# Altium schematic sheet [1]Power.SchDoc
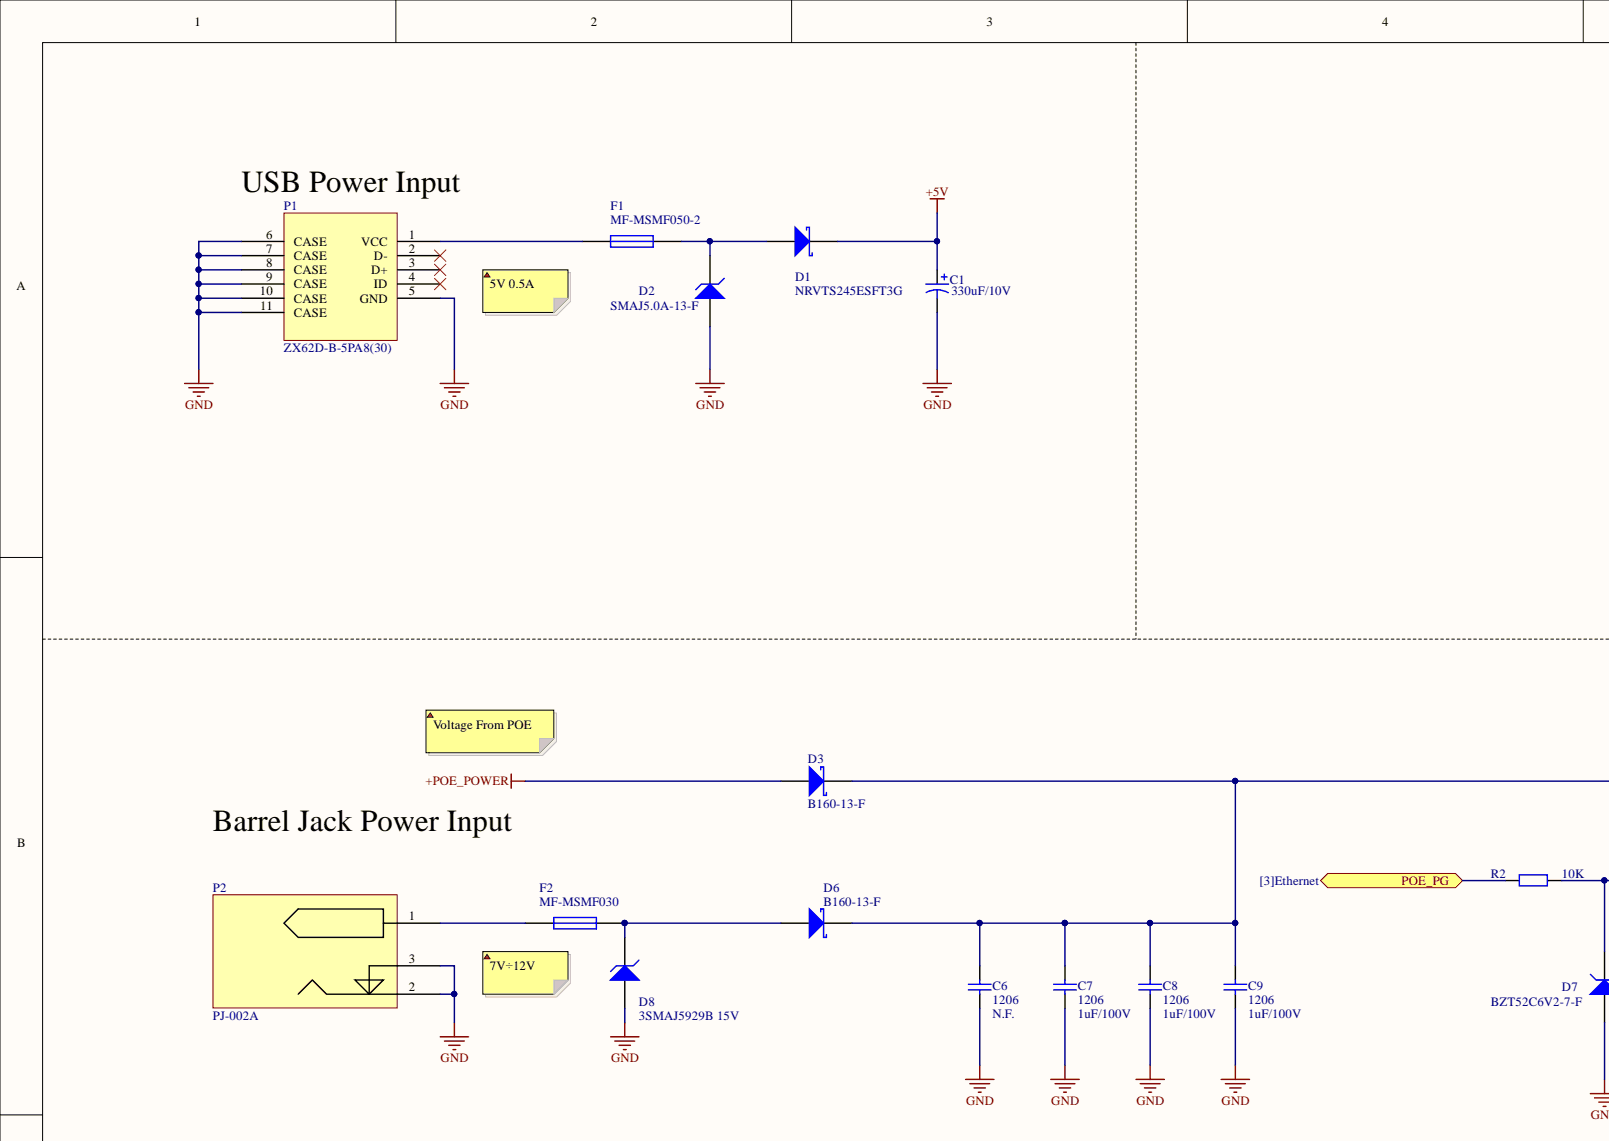
[diagram p0: [1]Power.SchDoc, top left]
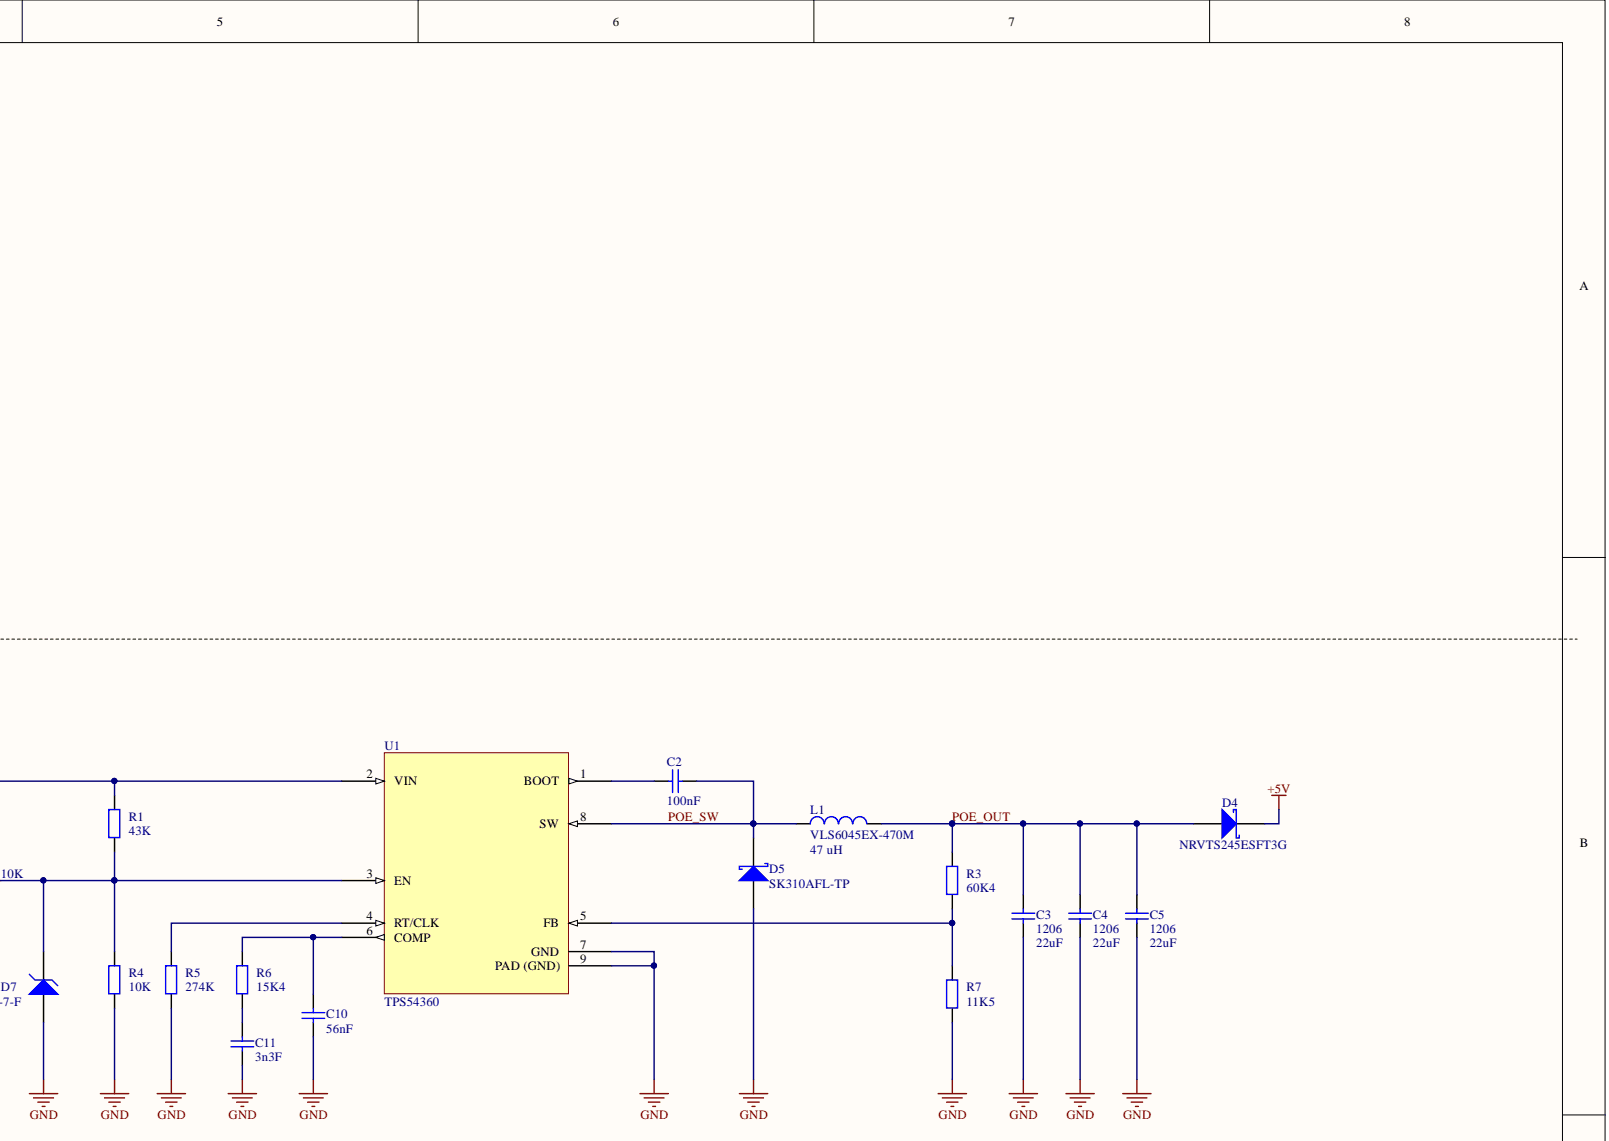
[diagram p1: [1]Power.SchDoc, top right]
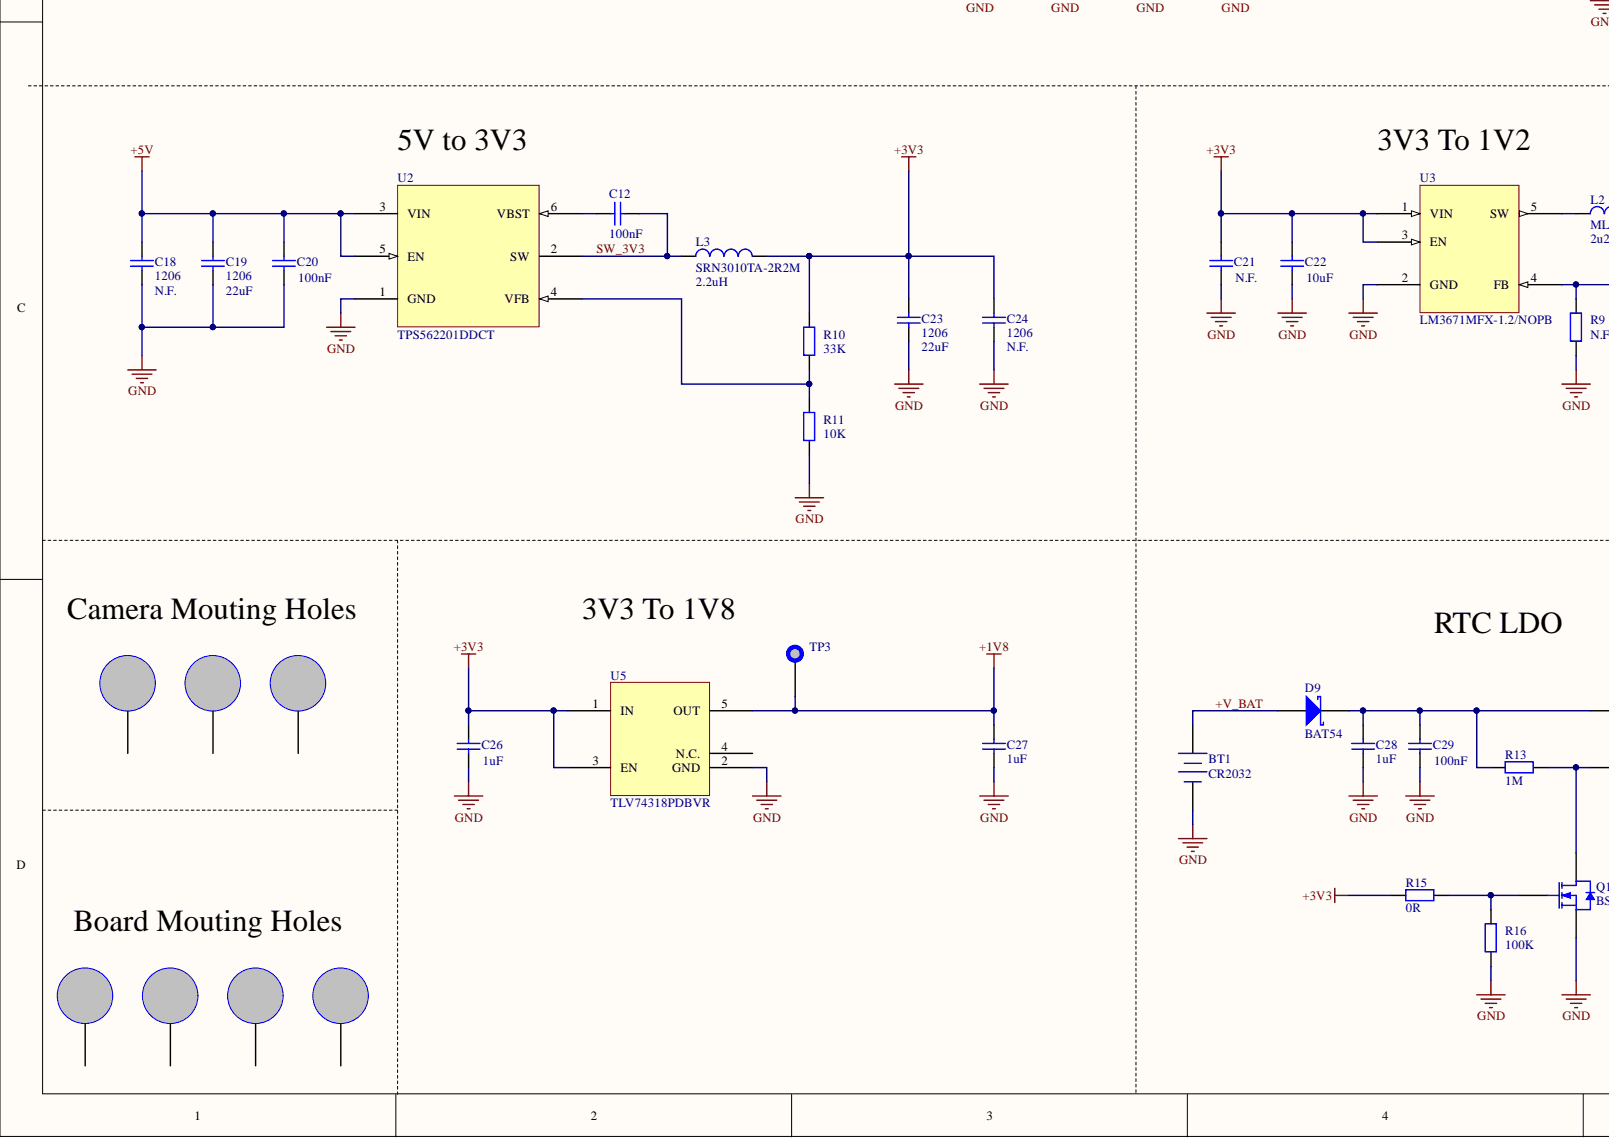
[diagram p2: [1]Power.SchDoc, bottom left]
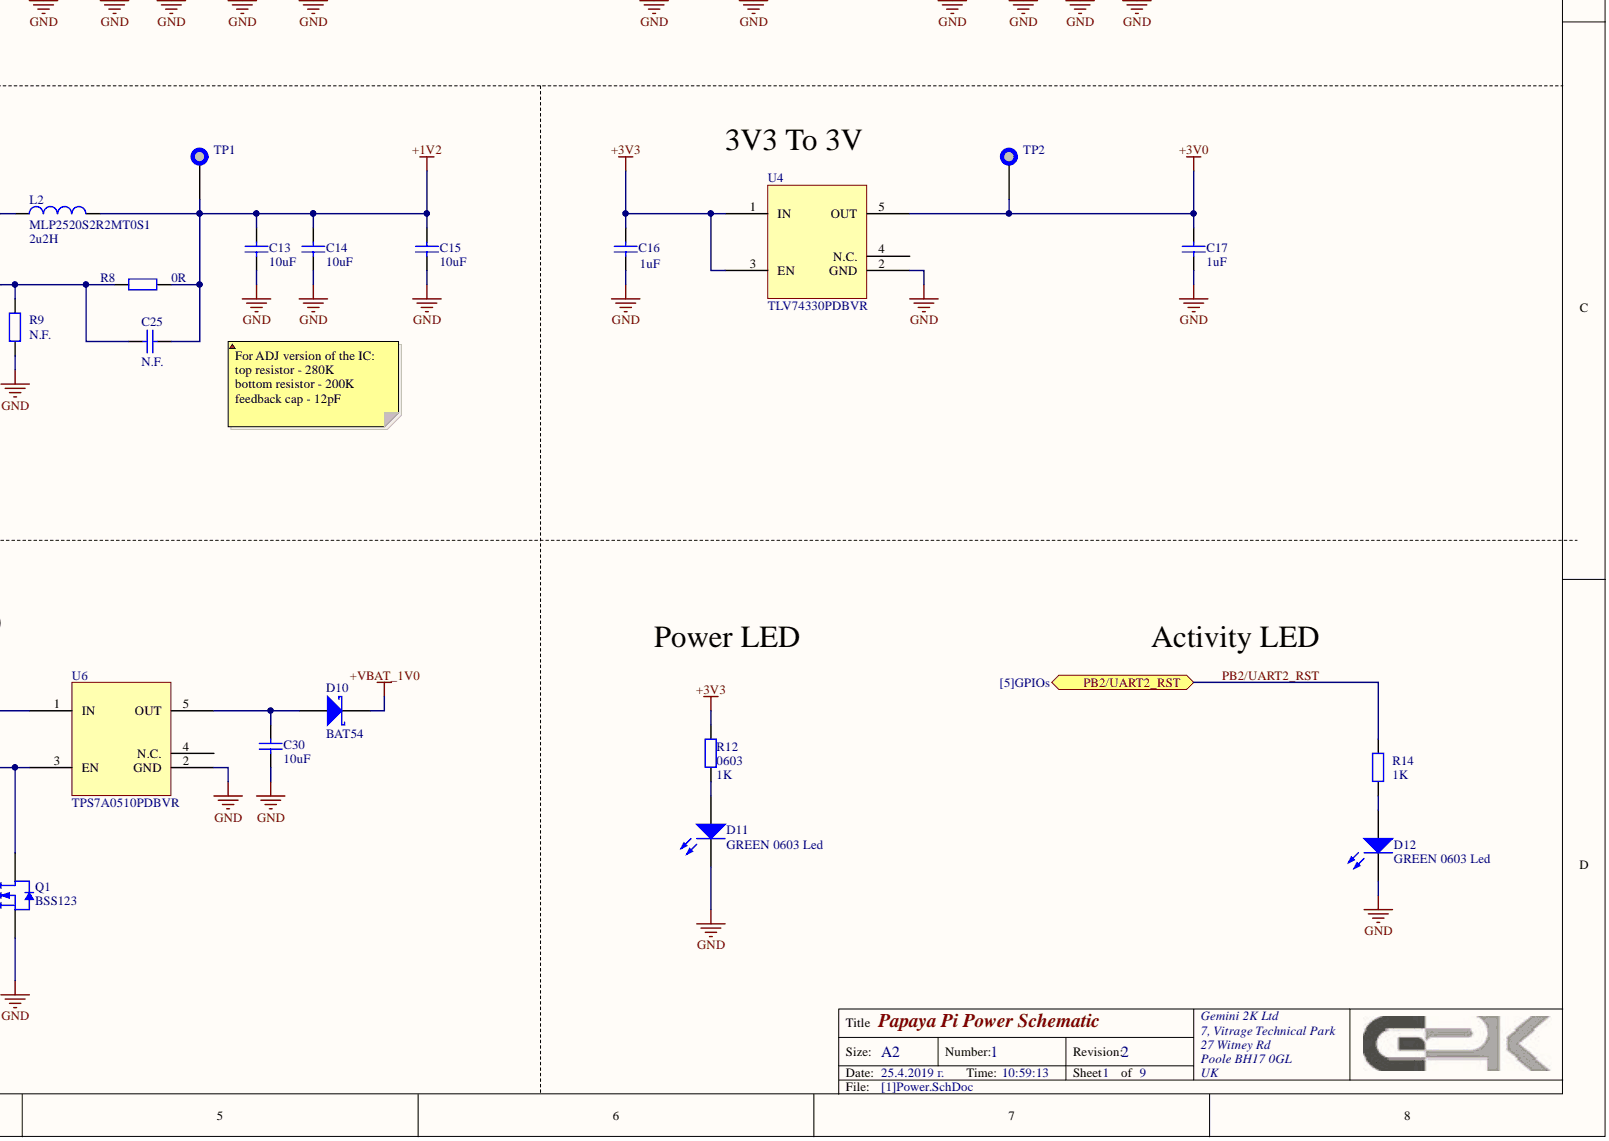
[diagram p3: [1]Power.SchDoc, bottom right]

## Parts
BT1  CR2032  [BAT-HLD-001]
C1  330uF/10V  [8x10mm Electrolytic cap]
C2  value 100nF  [1608[0603]]  (hidden comment: 0603)
C3  1206  value 22uF  [C1206]
C4  1206  value 22uF  [C1206]
C5  1206  value 22uF  [C1206]
C6  1206  value N.F.  [C1206]
C7  1206  value 1uF/100V  [C1206]
C8  1206  value 1uF/100V  [C1206]
C9  1206  value 1uF/100V  [C1206]
C10  value 56nF  [1608[0603]]  (hidden comment: 0603)
C11  value 3n3F  [1608[0603]]  (hidden comment: 0603)
C12  value 100nF  [1608[0603]]  (hidden comment: 0603)
C13  value 10uF  [1608[0603]]  (hidden comment: 0603)
C14  value 10uF  [1608[0603]]  (hidden comment: 0603)
C15  value 10uF  [1608[0603]]  (hidden comment: 0603)
C16  value 1uF  [1608[0603]]  (hidden comment: 0603)
C17  value 1uF  [1608[0603]]  (hidden comment: 0603)
C18  1206  value N.F.  [C1206]
C19  1206  value 22uF  [C1206]
C20  value 100nF  [1608[0603]]  (hidden comment: 0603)
C21  value N.F.  [1608[0603]]  (hidden comment: 0603)
C22  value 10uF  [1608[0603]]  (hidden comment: 0603)
C23  1206  value 22uF  [C1206]
C24  1206  value N.F.  [C1206]
C25  value N.F.  [1608[0603]]  (hidden comment: 0603)
C26  value 1uF  [1608[0603]]  (hidden comment: 0603)
C27  value 1uF  [1608[0603]]  (hidden comment: 0603)
C28  value 1uF  [1608[0603]]  (hidden comment: 0603)
C29  value 100nF  [1608[0603]]  (hidden comment: 0603)
C30  value 10uF  [1608[0603]]  (hidden comment: 0603)
D1  NRVTS245ESFT3G  [SOD-123FL]
D2  SMAJ5.0A-13-F  [SMA]
D3  B160-13-F  [SMA]
D4  NRVTS245ESFT3G  [SOD-123FL]
D5  SK310AFL-TP  [DO-221AC-2]
D6  B160-13-F  [SMA]
D7  BZT52C6V2-7-F  [SOD123]
D8  3SMAJ5929B 15V  [SMA]
D9  BAT54  [SOT-23_N]
D10  BAT54  [SOT-23_N]
D11  GREEN 0603 Led  [LED0603]
D12  GREEN 0603 Led  [LED0603]
F1  MF-MSMF050-2  [CAPC4532L]
F2  MF-MSMF030  [CAPC4532L]
L1  VLS6045EX-470M  value 47 uH  [VLS-EX Series Inductors]
L2  MLP2520S2R2MT0S1  value 2u2H  [1008 Inductor Case]
L3  SRN3010TA-2R2M  value 2.2uH  [SRN3012TA Series Inductors]
MH1  Mouting Hole  [M3 Mounting Hole]  (no BOM)
MH2  Mouting Hole  [M3 Mounting Hole]  (no BOM)
MH3  Mouting Hole  [M3 Mounting Hole]  (no BOM)
MH4  Mouting Hole  [M3 Mounting Hole]  (no BOM)
MH5  Mouting Hole  [M3 Mounting Hole]  (no BOM)
MH6  Mouting Hole  [M3 Mounting Hole]  (no BOM)
MH7  Mouting Hole  [M3 Mounting Hole]  (no BOM)
P1  ZX62D-B-5PA8(30)  [ZX62D-B-5PA8(30)]
P2  PJ-002A  [PJ-002A]
Q1  BSS123  [SOT23_N]
R1  value 43K  [J1-0603]  (hidden comment: 0603)
R2  value 10K  [J1-0603]  (hidden comment: 0603)
R3  value 60K4  [J1-0603]  (hidden comment: 0603)
R4  value 10K  [J1-0603]  (hidden comment: 0603)
R5  value 274K  [J1-0603]  (hidden comment: 0603)
R6  value 15K4  [J1-0603]  (hidden comment: 0603)
R7  value 11K5  [J1-0603]  (hidden comment: 0603)
R8  value 0R  [J1-0603]  (hidden comment: 0603)
R9  value N.F.  [J1-0603]  (hidden comment: 0603)
R10  value 33K  [J1-0603]  (hidden comment: 0603)
R11  value 10K  [J1-0603]  (hidden comment: 0603)
R12  0603  value 1K  [J1-0603]
R13  value 1M  [J1-0603]  (hidden comment: 0603)
R14  value 1K  [J1-0603]  (hidden comment: 0603)
R15  value 0R  [J1-0603]  (hidden comment: 0603)
R16  value 100K  [J1-0603]  (hidden comment: 0603)
TP1  TEST_POINT  [TEST_POINT]  (value not drawn)  (no BOM)
TP2  TEST_POINT  [TEST_POINT]  (value not drawn)  (no BOM)
TP3  TEST_POINT  [TEST_POINT]  (value not drawn)  (no BOM)
U1  TPS54360  [HSOIC-8]
U2  TPS562201DDCT  [SOT100P275-6L]
U3  LM3671MFX-1.2/NOPB  [SOT23-5AN]
U4  TLV74330PDBVR  [SOT23-5AN]
U5  TLV74318PDBVR  [SOT23-5AN]
U6  TPS7A0510PDBVR  [SOT23-5AN]

## Ports
PB2/UART2_RST, POE_PG

## Nets
+1V2: C13.2 C14.2 C15.2 C25.1 L2.2 R8.1 TP1.1
+1V8: C27.2 TP3.1 U5.5(OUT)
+3V0: C17.2 TP2.1 U4.5(OUT)
+3V3: C16.2 C21.2 C22.2 C23.2 C24.2 C26.2 L3.2 R10.2 R12.1 R15.1 U3.1(VIN) U3.3(EN) U4.1(IN) U4.3(EN) U5.1(IN) U5.3(EN)
+5V: C1.1 C18.2 C19.2 C20.2 D1.2 D4.2 U2.3(VIN) U2.5(EN)
+POE_POWER: D3.1
+VBAT_1V0: D10.3
GND: BT1.2 C1.2 C3.1 C4.1 C5.1 C6.1 C7.1 C8.1 C9.1 C10.1 C11.1 C13.1 C14.1 C15.1 C16.1 C17.1 C18.1 C19.1 C20.1 C21.1 C22.1 C23.1 C24.1 C26.1 C27.1 C28.1 C29.1 C30.1 D2.1 D5.1 D7.1 D8.1 D11.2 D12.2 P1.5(GND) P1.6(CASE) P1.7(CASE) P1.8(CASE) P1.9(CASE) P1.10(CASE) P1.11(CASE) P2.2 P2.3 Q1.2 R4.1 R5.1 R7.1 R9.1 R11.1 R16.1 U1.7(GND) U1.9(PAD_(GND)) U2.1(GND) U3.2(GND) U4.2(GND) U5.2(GND) U6.2(GND)
+V_BAT: BT1.1 D9.1
PB2/UART2_RST: R14.1 ; port PB2/UART2_RST
POE_OUT: C3.2 C4.2 C5.2 D4.1 L1.2 R3.2
POE_PG: R2.2 ; port POE_PG
POE_SW: C2.1 D5.2 L1.1 U1.8(SW)
SW_3V3: C12.1 L3.1 U2.2(SW)
NetC2_2: C2.2 U1.1(BOOT)
NetC6_2: C6.2 C7.2 C8.2 C9.2 D3.2 D6.2 R1.2 U1.2(VIN)
NetC10_2: C10.2 R6.2 U1.6(COMP)
NetC11_2: C11.2 R6.1
NetC12_2: C12.2 U2.6(VBST)
NetC25_2: C25.2 R8.2 R9.2 U3.4(FB)
NetC28_2: C28.2 C29.2 D9.3 R13.2 U6.1(IN)
NetC30_2: C30.2 D10.1 U6.5(OUT)
NetD1_1: D1.1 D2.2 F1.2
NetD6_1: D6.1 D8.2 F2.2
NetD7_2: D7.2 R1.1 R2.1 R4.2 U1.3(EN)
NetD11_1: D11.1 R12.2
NetD12_1: D12.1 R14.2
NetF1_1: F1.1 P1.1(VCC)
NetF2_1: F2.1 P2.1
NetL2_1: L2.1 U3.5(SW)
NetQ1_1: Q1.1 R15.2 R16.2
NetQ1_3: Q1.3 R13.1 U6.3(EN)
NetR3_1: R3.1 R7.2 U1.5(FB)
NetR5_2: R5.2 U1.4(RT/CLK)
NetR10_1: R10.1 R11.2 U2.4(VFB)

Not connected: MH1 1; MH2 1; MH3 1; MH4 1; MH5 1; MH6 1; MH7 1; P1 2(D-) 3(D+) 4(ID); U4 4(N.C.); U5 4(N.C.); U6 4(N.C.)

## Library data of the parts
BT1 = Battery: Multicell Battery; Manufacturer Name: Linx Technologies; Component Kind: Standard; Distributor Link: https://eu.mouser.com/ProductDetail/Linx-Technologies/BAT-HLD-001?qs=K5ta8V%252bWhta7hbVGfm4dqA%3D%3D; LatestRevisionDate: 17-Jul-2002; LatestRevisionNote: Re-released for DXP Platform.; Library Name: Miscellaneous Devices.IntLib; Manufacturer Part No.: BAT-HLD-001; PackageDescription: Battery; 2 Leads; PackageReference: BAT-2; Published: 24-Mar-1999; Publisher: Altium Limited
C1 = Cap Pol3: Polarized Capacitor (Surface Mount); Manufacturer Name: Nichicon; Manufacturer Part No.: UWT1A331MNL1GS; G2K_PN: G-CAP-C22UF-UUR2A220MNL1GS-01; Component Kind: Standard; Distributor Link: https://eu.mouser.com/ProductDetail/Nichicon/UWT1A331MNL1GS?qs=%2Fha2pyFadugs9ug9Ltm1CEeY4iN9OVWAGUV2SBjTxwrrhxchLNuxOQ%3D%3D; Library Name: Miscellaneous Devices.IntLib; Value: 330uF/10V
C2 C12 C20 C29 = Cap: Capacitor; Note: We have them in Bulgaria; Case Code (in): 0603; Component Kind: Standard; Distributor Link: http://uk.farnell.com/kemet/c0603x104k4ractu/cap-mlcc-x7r-100nf-16v-0603/dp/1414027; LatestRevisionDate: 17-Jul-2002; LatestRevisionNote: Re-released for DXP Platform.; Library Name: Miscellaneous Devices.IntLib; PackageDescription: Radial Cap, Thru-Hole; 2 Leads; 0.3 in Pin Spacing; PackageReference: RAD-0.3; Published: 8-Jun-2000; Signal Integrity: Cap; Simulation: CAP; Publisher: Altium Limited
C3-C9 C13-C19 C21-C28 C30 = Cap: Capacitor; Component Kind: Standard; LatestRevisionDate: 17-Jul-2002; LatestRevisionNote: Re-released for DXP Platform.; Library Name: Miscellaneous Devices.IntLib; PackageDescription: Radial Cap, Thru-Hole; 2 Leads; 0.3 in Pin Spacing; PackageReference: RAD-0.3; Published: 8-Jun-2000; Signal Integrity: Cap; Simulation: CAP; Publisher: Altium Limited
  C3-C5 C19 C23: Case Code (in): 1206; Distributor Link: https://eu.mouser.com/ProductDetail/Taiyo-Yuden/EMK316BB7226ML-T?qs=sGAEpiMZZMvsSlwiRhF8qty1BJkHnpVYblTC1OBiWryHLK40sNpKgw%3d%3d
  C6 C18 C24: Case Code (in): 1206
  C7-C9: Case Code (in): 1206; Distributor Link: https://uk.farnell.com/avx/12061c105kat2a/cap-1-f-100v-10-x7r-1206/dp/1657939
  C13-C15 C22 C30: Case Code (in): 0603; Distributor Link: https://eu.mouser.com/ProductDetail/Taiyo-Yuden/JMK107ABJ106MA-T?qs=sGAEpiMZZMvsSlwiRhF8qtYrDJZp5VWM0KJHW32Kpw4hdLhJsFnoCA%3d%3d
  C16 C17 C26-C28: Case Code (in): 0603; Distributor Link: https://eu.mouser.com/ProductDetail/Taiyo-Yuden/LMK107B7105KA-T?qs=sGAEpiMZZMvsSlwiRhF8qgJCQaFFkNE%2fsetabqB5pzo%3d
  C21 C25: Case Code (in): 0603
C10 C11 = Cap: Capacitor; Note: Get a lot more of those.; Case Code (in): 0603; Component Kind: Standard; LatestRevisionDate: 17-Jul-2002; LatestRevisionNote: Re-released for DXP Platform.; Library Name: Miscellaneous Devices.IntLib; PackageDescription: Radial Cap, Thru-Hole; 2 Leads; 0.3 in Pin Spacing; PackageReference: RAD-0.3; Published: 8-Jun-2000; Signal Integrity: Cap; Simulation: CAP; Publisher: Altium Limited
  C10: Distributor Link: https://uk.farnell.com/kemet/c0603c563k5ractu/cap-0-056-f-50v-10-x7r-0603/dp/1865538
  C11: Distributor Link: https://uk.farnell.com/multicomp/mc0603b332k500ct/cap-3300pf-50v-10-x7r-0603/dp/1759095
D1 D4 = D Schottky: Schottky Diode; Manufacturer Name: ON Semiconductor; Component Kind: Standard; Library Name: Miscellaneous Devices.IntLib; Manufacturer Part No.: NRVTS245ESFT3G
  D1: Distributor Link: https://eu.mouser.com/ProductDetail/ON-Semiconductor/NRVTS245ESFT3G?qs=%2fha2pyFaduhXdiVT9CFLR0%2fbKNWkiKHdgiRqQBSSTZVuU0rdVrgZUQ%3d%3d
  D4: Distributor Link: https://eu.mouser.com/ProductDetail/ON-Semiconductor/NRVTS245ESFT3G?qs=k6dkFvHqcvCQU%252bJeAqXeng%3D%3D
D2 = D Zener: Zener Diode; Manufacturer Name: Diodes Incorporated; G2K_PN: G-ZEN-SMAJ5.0A-01; Component Kind: Standard; Distributor Link: https://eu.mouser.com/ProductDetail/Diodes-Incorporated/SMAJ50A-13-F?qs=%2fha2pyFaduh7RhMkydd9YvFtdDGNP%252brahhrS%2fZjE8zmRORqU3Z0Klg%3d%3d; LatestRevisionDate: 17-Jul-2002; LatestRevisionNote: Re-released for DXP Platform.; Library Name: Miscellaneous Devices.IntLib; Manufacturer Part No.: SMAJ5.0A-13-F; PackageReference: DIODE-0.7; Published: 8-Jun-2000; Signal Integrity: D Zener; Simulation: ZENER; Publisher: Altium Limited
D3 D6 = D Schottky: Schottky Diode; Manufacturer Name: Diodes Incorporated; Code_JEDEC: DO-214-AA; Component Kind: Standard; Distributor Link: https://eu.mouser.com/ProductDetail/Diodes-Incorporated/B160-13-F?qs=SQxTiZ%252BdFLDKHRPMwjkKCg%3D%3D; LatestRevisionDate: 17-Jul-2002; LatestRevisionNote: Re-released for DXP Platform.; Library Name: Miscellaneous Devices.IntLib; Manufacturer Part No.: B160-13-F; PackageReference: SMB; Published: 8-Jun-2000; Publisher: Altium Limited
D5 = D Schottky: Schottky Diode; Manufacturer Name: Micro Commercial Components (MCC); Note: Get about 5 more of this; Code_JEDEC: DO-214-AA; Component Kind: Standard; Distributor Link: https://eu.mouser.com/ProductDetail/Micro-Commercial-Components-MCC/SK310AFL-TP?qs=%2F9jDaHz9Z2jGR2bZfe%252bg%2FQ%3D%3D; LatestRevisionDate: 17-Jul-2002; LatestRevisionNote: Re-released for DXP Platform.; Library Name: Miscellaneous Devices.IntLib; Manufacturer Part No.: SK310AFL-TP; PackageDescription: DO-214-AA/SMB; 2 C-Bend Leads; Body 5.3 x 3.6 mm, inc. leads (LxW); PackageReference: SMB; Published: 8-Jun-2000; Signal Integrity: D Schottky; Simulation: SKYDIODE; Publisher: Altium Limited
D7 = D Zener: Zener Diode; Manufacturer Name: Diodes Incorporated; Component Kind: Standard; Distributor Link: https://eu.mouser.com/ProductDetail/Diodes-Incorporated/BZT52C6V2-7-F?qs=sGAEpiMZZMtQ8nqTKtFS%2FB3EXccNg%252BLy7eRreKkDmjQ%3D; LatestRevisionDate: 17-Jul-2002; LatestRevisionNote: Re-released for DXP Platform.; Library Name: Miscellaneous Devices.IntLib; Manufacturer Part No.: BZT52C6V2-7-F; PackageDescription: Diode, Thru-Hole; 2 Leads; 0.7 in Pin Spacing; PackageReference: DIODE-0.7; Published: 8-Jun-2000; Signal Integrity: D Zener; Simulation: ZENER; Publisher: Altium Limited
D8 = D Zener: Zener Diode; Manufacturer Name: Micro Commercial Components (MCC); Component Kind: Standard; Distributor Link: https://eu.mouser.com/ProductDetail/Micro-Commercial-Components-MCC/SMAJ5929B-TP?qs=sGAEpiMZZMtQ8nqTKtFS%2FNu8%252Bgw6C5jShKXC53ar3gg%3D; LatestRevisionDate: 17-Jul-2002; LatestRevisionNote: Re-released for DXP Platform.; Library Name: Miscellaneous Devices.IntLib; Manufacturer Part No.: SMAJ5929B; PackageReference: DIODE-0.7; Published: 8-Jun-2000; Signal Integrity: D Zener; Simulation: ZENER; Publisher: Altium Limited
D9 D10 = Diode BAT17: Silicon RF Schottky Diode for Mixer Applications in the VHF/UHF Range; Manufacturer Name: ON SEMICONDUCTOR; Manufacturer Part No.: BAT54LT1G; Component Kind: Standard; ComponentLink1Description: Manufacturer Link; ComponentLink1URL: http://www.infineon.com/; ComponentLink2Description: Datasheet; ComponentLink2URL: http://www.infineon.com/upload/Document/cmc_upload/documents/009/363/bat17series.pdf; DatasheetVersion: Oct-1999; Distributor Link: https://uk.farnell.com/on-semiconductor/bat54lt1g/diode-signal-30v-sot-23/dp/1431113; LatestRevisionDate: 29-May-2009; LatestRevisionNote: IPC-7351 Footprint Added.; Library Name: Miscellaneous Devices.IntLib; PackageDescription: 3-Pin SOT-23 Package 0.95 mm Pitch, 2.5 mm Lead Span; PackageReference: SOT-23; PackageVersion: Sep-2001; Published: 21-Mar-2003; Simulation: D188; Publisher: Altium Limited
D11 D12 = LED0: Typical INFRARED GaAs LED; Manufacturer Name: KINGBRIGHT; Manufacturer Part No.: KPT-1608CGCK; Note: We have them in Bulgaria; Component Kind: Standard; Distributor Link: http://uk.farnell.com/kingbright/kpt-1608cgck/led-green-0603-smd/dp/2099220; LatestRevisionDate: 08-Jul-2005; LatestRevisionNote: Mech Layer 1 removed.; Library Name: Miscellaneous Devices.IntLib; PackageDescription: LED; 2 Leads; PackageReference: LED-0; Published: 8-Jun-2000; Simulation: LED0; Publisher: Altium Limited
F1 F2 = Fuse 1: Fuse; Manufacturer Name: Bourns; Component Kind: Standard; LatestRevisionDate: 17-Jul-2002; LatestRevisionNote: Re-released for DXP Platform.; Library Name: Miscellaneous Devices.IntLib; PackageReference: PIN-W2/E2.8; Published: 8-Jun-2000; Simulation: FUSE; Publisher: Altium Limited
  F1: Distributor Link: https://uk.farnell.com/bourns/mf-msmf050-2/fuse-ptc-reset-15v-0-5a-smd/dp/9350314RL; Manufacturer Part No.: MF-MSMF050-2
  F2: Distributor Link: https://eu.mouser.com/ProductDetail/Bourns/MF-MSMF030-2?qs=4Enggx7jqVwuh3vnyeU3lA%3D%3D; Manufacturer Part No.: MF-MSMF030
L1 = Inductor: Manufacturer Name: TDK; Code_IEC: 1005; Note: Get about 5 more of this; Code_JEITA: 0402; Component Kind: Standard; Description: Inductor; Distributor Link: https://uk.farnell.com/tdk/vls6045ex-470m/inductor-47uh-1-3a-20-wirewound/dp/2776731RL; LatestRevisionDate: 29-May-2009; LatestRevisionNote: IPC-7351 Footprint Added.; Library Name: Miscellaneous Devices.IntLib; Manufacturer Part No.: VLS6045EX-470M; PackageDescription: Chip Inductor; PackageReference: 0402-A; Published: 8-Jun-2000; Signal Integrity: Inductor; Simulation: INDUCTOR; Publisher: Altium Limited
L2 = Inductor: Manufacturer Name: TDK; Code_IEC: 1005; Code_JEITA: 0402; Component Kind: Standard; Description: Inductor; Distributor Link: https://eu.mouser.com/ProductDetail/TDK/MLP2520S2R2MT0S1?qs=%2fha2pyFaduh0gxrgKIlsYSXs17RCvloMFZx7ufg9aiWiXRBut5TeuA%3d%3d; LatestRevisionDate: 29-May-2009; LatestRevisionNote: IPC-7351 Footprint Added.; Library Name: Miscellaneous Devices.IntLib; Manufacturer Part No.: MLP2520S2R2MT0S1; PackageDescription: Chip Inductor; PackageReference: 0402-A; Published: 8-Jun-2000; Signal Integrity: Inductor; Simulation: INDUCTOR; Publisher: Altium Limited
L3 = Inductor: Manufacturer Name: Bourns; Manufacturer Part No.: SRN3012TA-2R2M; Code_IEC: 1005; Code_JEITA: 0402; Component Kind: Standard; Description: Inductor; Distributor Link: https://eu.mouser.com/ProductDetail/Bourns/SRN3012TA-2R2M?qs=Vt59ZOdFuWbjnb9HYKJFZA%3D%3D; LatestRevisionDate: 29-May-2009; LatestRevisionNote: IPC-7351 Footprint Added.; Library Name: Miscellaneous Devices.IntLib; PackageDescription: Chip Inductor; PackageReference: 0402-A; Published: 8-Jun-2000; Signal Integrity: Inductor; Simulation: INDUCTOR; Publisher: Altium Limited
P1 = ZX62D-B-5PA8(30): USB Mini Connector Female; Manufacturer Name: HIROSE(HRS); Component Kind: Standard; Distributor Link: https://uk.farnell.com/hirose-hrs/zx62d-b-5pa8-30/micro-usb-2-0-type-b-rcpt-smt/dp/2554981; Manufacturer Part No.: ZX62D-B-5PA8(30); Library Name: PapayaPi.SchLib
P2 = PJ-002A: DC Power Jack Connector; Manufacturer Name: CUI; Component Kind: Standard; Distributor Link: https://eu.mouser.com/ProductDetail/CUI/PJ-002A?qs=WyjlAZoYn51CKfAix9Mngw%3D%3D; Manufacturer Part No.: PJ-002A; Library Name: PapayaPi.SchLib
Q1 = BSS123: N-channel Enhancement Mode Vertical D-MOSFET; Manufacturer Name: ON Semiconductor; Component Kind: Standard; ComponentLink1Description: Manufacturer Link; ComponentLink1URL: http://www.semiconductors.philips.com/; ComponentLink2Description: Datasheet; ComponentLink2URL: http://www.semiconductors.philips.com/acrobat/datasheets/BSS123_3.pdf; DatasheetVersion: Apr-1995; Distributor Link: https://eu.mouser.com/ProductDetail/ON-Semiconductor/BSS123LT1G?qs=sGAEpiMZZMshyDBzk1%2fWizSW%252bwlCH%2fhMYWCTWBfWgg8%3d; LatestRevisionDate: 12-Dec-2006; LatestRevisionNote: IPC-7351 Footprint Added.; Library Name: Philips Discrete MOSFET - Low Power.IntLib; Manufacturer Part No.: BSS123; PackageDescription: 3-Pin SOT-23 Package 0.95 mm Pitch, 2.3 mm Lead Span; PackageReference: SOT23; PackageVersion: May-1999; Published: 17-Sep-2003; Simulation: BSS123; Publisher: Altium Limited
R1-R7 R9-R14 R16 = Res2: Resistor; Case Code (in): 0603; Component Kind: Standard; LatestRevisionDate: 17-Jul-2002; LatestRevisionNote: Re-released for DXP Platform.; Library Name: Miscellaneous Devices.IntLib; PackageDescription: Axial Device, Thru-Hole; 2 Leads; 0.4 in Pin Spacing; PackageReference: AXIAL-0.4; Published: 8-Jun-2000; Signal Integrity: Res; Simulation: RESISTOR; Publisher: Altium Limited
  R1: Distributor Link: https://uk.farnell.com/vishay/crcw060343k0fkea/res-43k-1-0-1w-0603-thick-film/dp/1469809
  R2 R4 R11: Distributor Link: http://uk.farnell.com/multicomp/mcwr06x1002ftl/res-thick-film-10k-1-0-1w-0603/dp/2447230
  R3: Distributor Link: https://uk.farnell.com/vishay/crcw060360k4fkea/res-60k4-1-0-1w-0603-thick-film/dp/2138476
  R5: Distributor Link: https://uk.farnell.com/panasonic-electronic-components/erj3ekf2743v/res-274k-1-0-1w-0603-thick-film/dp/2303263
  R6: Distributor Link: https://uk.farnell.com/panasonic-electronic-components/erj3ekf1542v/res-15k4-1-0-1w-0603-thick-film/dp/2303198
  R7: Distributor Link: https://uk.farnell.com/vishay/crcw060311k5fkea/res-11k5-1-0-1w-0603-thick-film/dp/1469753
  R10: Distributor Link: https://eu.mouser.com/ProductDetail/Yageo/RC0603FR-0733KL?qs=sGAEpiMZZMvdGkrng054t8Tx25L%252bvTaR4CpLvckkWgM%3d
  R12 R14: Distributor Link: https://eu.mouser.com/ProductDetail/ROHM-Semiconductor/ESR03EZPJ102?qs=sGAEpiMZZMvdGkrng054t4QdAY%2fQ0LwehhDMd9V6YVc%3d
  R13: Distributor Link: https://uk.farnell.com/yageo/rc0603fr-071ml/res-1m-1-0-1w-0603-thick-film/dp/9238840
  R16: Distributor Link: https://eu.mouser.com/ProductDetail/Vishay-Draloric/RCA0603100KJNEC?qs=sGAEpiMZZMvdGkrng054twKDKoBh%252bscnvgxPku908uc%3d
R8 R15 = Res2: Resistor; Note: We have them in Bulgaria; Case Code (in): 0603; Component Kind: Standard; Distributor Link: http://uk.farnell.com/yageo/rc0603fr-070rl/res-thick-film-0r-1-0-1w-0603/dp/2309106; LatestRevisionDate: 17-Jul-2002; LatestRevisionNote: Re-released for DXP Platform.; Library Name: Miscellaneous Devices.IntLib; PackageDescription: Axial Device, Thru-Hole; 2 Leads; 0.4 in Pin Spacing; PackageReference: AXIAL-0.4; Published: 8-Jun-2000; Signal Integrity: Res; Simulation: RESISTOR; Publisher: Altium Limited
U1 = TPS54360: 60-V Input, 3.5-A, Step-Down DC/DC Converter With Eco-Mode; Manufacturer Name: Texas Instruments; Manufacturer Part No.: TPS54360DDAR; Note: TPS54360 is NRFND, if its out of stock, get TPS54360B; Component Kind: Standard; Distributor Link: https://eu.mouser.com/ProductDetail/Texas-Instruments/TPS54360DDAR?qs=sGAEpiMZZMtitjHzVIkrqQ%2fpYjwcDHoFDywU%252bodbKiA%3d; Library Name: PapayaPi.SchLib
U2 = TPS56x20x: Synchronous Step-Down Converters; Manufacturer Name: Texas Instruments; Component Kind: Standard; Distributor Link: https://eu.mouser.com/Texas-Instruments/Switching-Voltage-Regulators/TPS562201-Series/_/N-1z0zls6Z668jtZ1yjfu3l; Manufacturer Part No.: TPS562201DDCT; Library Name: G2Pay_Lib.SchLib
U3 = LM3671MF Series: LM3671/-Q1 2-MHz, 600-mA Step-Down DC-DC Converter; Manufacturer Name: Texas Instruments; Component Kind: Standard; Distributor Link: https://eu.mouser.com/ProductDetail/Texas-Instruments/LM3671MFX-12-NOPB?qs=sGAEpiMZZMtitjHzVIkrqaeIqJaO9bXJL8M2cS7OPbk%3d; Manufacturer Part No.: LM3671MFX-1.2/NOPB; Library Name: G2Pay_Lib.SchLib
U4-U6 = TI_LDO_SOT23: Case for chips like TLV755P series and TLV743P; Manufacturer Name: Texas Instruments; Component Kind: Standard; Library Name: G2Pay_Lib.SchLib
  U4: Distributor Link: https://eu.mouser.com/ProductDetail/Texas-Instruments/TLV74330PDBVR?qs=%2fha2pyFaduhWwpp3YjZZlkzuPnb4oDcE9Ws1N5jx7teoeJ72Q1IeeQ%3d%3d; Manufacturer Part No.: TLV74330PDBVR
  U5: Distributor Link: https://eu.mouser.com/ProductDetail/Texas-Instruments/TLV74318PDBVR?qs=%2fha2pyFadugopIlzAXy4%252biykMZQGwpGt8xZ1einGBXu0NDan53omUg%3d%3d; Manufacturer Part No.: TLV74318PDBVR
  U6: Distributor Link: https://eu.mouser.com/ProductDetail/Texas-Instruments/TPS7A0510PDBVR?qs=vdi0iO8H4N0oKOZyo1KPdg%3D%3D; Manufacturer Part No.: TPS7A0510PDBVR
MH1-MH7 = Mouting Hole: Library Name: custom_components.SchLib
TP1-TP3 = TEST_POINT: Library Name: OrbitCrypto.SchLib
